# Altium schematic sheet Power Distribution - Misc Boards.SchDoc("Power Distribution - Misc Boards")
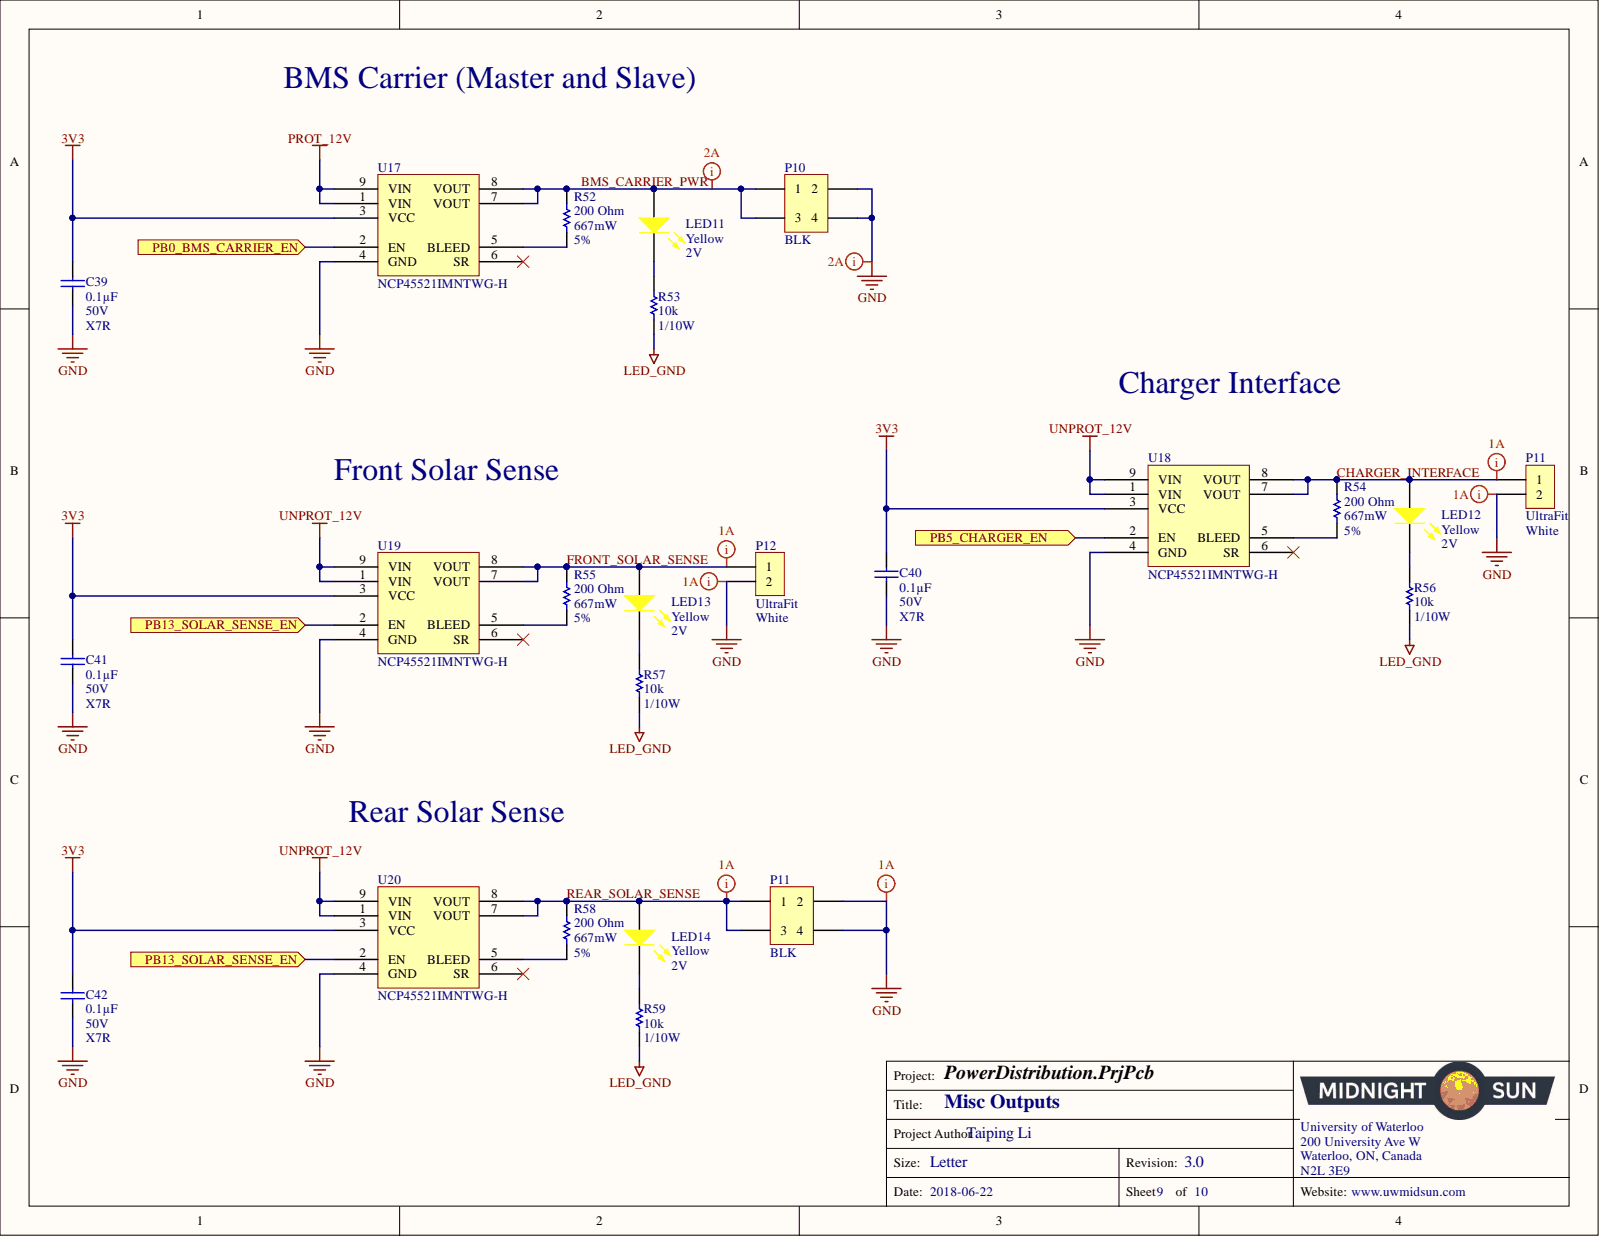
[diagram p0: Power Distribution - Misc Boards.SchDoc]

## Parts
C39  Voltage: 50V  Temperature Coefficient: X7R  Capacitance: 0.1µF  [CAP, 0603]  (hidden comment: CAP CER 0.1UF 50V 10% X7R 0603)
C40  Voltage: 50V  Temperature Coefficient: X7R  Capacitance: 0.1µF  [CAP, 0603]  (hidden comment: CAP CER 0.1UF 50V 10% X7R 0603)
C41  Voltage: 50V  Temperature Coefficient: X7R  Capacitance: 0.1µF  [CAP, 0603]  (hidden comment: CAP CER 0.1UF 50V 10% X7R 0603)
C42  Voltage: 50V  Temperature Coefficient: X7R  Capacitance: 0.1µF  [CAP, 0603]  (hidden comment: CAP CER 0.1UF 50V 10% X7R 0603)
LED11  Voltage - Forward (Vf) (Typ): 2V  Color: Yellow  [LED_0603]  (hidden comment: LED YELLOW CLEAR 2.1V 0603)
LED12  Voltage - Forward (Vf) (Typ): 2V  Color: Yellow  [LED_0603]  (hidden comment: LED YELLOW CLEAR 2.1V 0603)
LED13  Voltage - Forward (Vf) (Typ): 2V  Color: Yellow  [LED_0603]  (hidden comment: LED YELLOW CLEAR 2.1V 0603)
LED14  Voltage - Forward (Vf) (Typ): 2V  Color: Yellow  [LED_0603]  (hidden comment: LED YELLOW CLEAR 2.1V 0603)
P10  BLK  [CONN, 4POS 2 ROW ULTRA-FIT]
P11  Series: UltraFit  Color: White  [CONN, 2POS ULTRA-FIT - NATURAL COLOR]  (hidden comment: CONN 2POS ULTRA-FIT NATURAL COLOR 0.138")
P11  BLK  [CONN, 4POS 2 ROW ULTRA-FIT]
P12  Series: UltraFit  Color: White  [CONN, 2POS ULTRA-FIT - NATURAL COLOR]  (hidden comment: CONN 2POS ULTRA-FIT NATURAL COLOR 0.138")
R52  200 Ohm  Tolerance: 5%  Max Power Dissipation: 667mW  [RES 1206]
R53  Resistance: 10k  Power: 1/10W  [RES, 0603]  (hidden comment: RES 10K OHM 1% 1/10W 0603)
R54  200 Ohm  Tolerance: 5%  Max Power Dissipation: 667mW  [RES 1206]
R55  200 Ohm  Tolerance: 5%  Max Power Dissipation: 667mW  [RES 1206]
R56  Resistance: 10k  Power: 1/10W  [RES, 0603]  (hidden comment: RES 10K OHM 1% 1/10W 0603)
R57  Resistance: 10k  Power: 1/10W  [RES, 0603]  (hidden comment: RES 10K OHM 1% 1/10W 0603)
R58  200 Ohm  Tolerance: 5%  Max Power Dissipation: 667mW  [RES 1206]
R59  Resistance: 10k  Power: 1/10W  [RES, 0603]  (hidden comment: RES 10K OHM 1% 1/10W 0603)
U17  IC LOAD SWITCH ACT-HI 10.5A 8DFN  [IC LOAD SWITCH ACT-HI 10.5A 8DFN]  (value not drawn)
U18  IC LOAD SWITCH ACT-HI 10.5A 8DFN  [IC LOAD SWITCH ACT-HI 10.5A 8DFN]  (value not drawn)
U19  IC LOAD SWITCH ACT-HI 10.5A 8DFN  [IC LOAD SWITCH ACT-HI 10.5A 8DFN]  (value not drawn)
U20  IC LOAD SWITCH ACT-HI 10.5A 8DFN  [IC LOAD SWITCH ACT-HI 10.5A 8DFN]  (value not drawn)

## Ports
PB0_BMS_CARRIER_EN, PB5_CHARGER_EN, PB13_SOLAR_SENSE_EN

## Nets
3V3: C39.1 C40.1 C41.1 C42.1 U17.3(VCC) U18.3(VCC) U19.3(VCC) U20.3(VCC)
GND: C39.2 C40.2 C41.2 C42.2 P10.2 P10.4 P11.2 P11.4 P12.2 U17.4(GND) U18.4(GND) U19.4(GND) U20.4(GND)
LED_GND: R53.2 R56.2 R57.2 R59.2
PROT_12V: U17.1(VIN) U17.9(VIN)
UNPROT_12V: U18.1(VIN) U18.9(VIN) U19.1(VIN) U19.9(VIN) U20.1(VIN) U20.9(VIN)
BMS_CARRIER_PWR: LED11.1 P10.1 P10.3 R52.1 U17.7(VOUT) U17.8(VOUT)
CHARGER_INTERFACE: LED12.1 P11.1 R54.1 U18.7(VOUT) U18.8(VOUT)
FRONT_SOLAR_SENSE: LED13.1 P12.1 R55.1 U19.7(VOUT) U19.8(VOUT)
PB0_BMS_CARRIER_EN: U17.2(EN) ; port PB0_BMS_CARRIER_EN
PB5_CHARGER_EN: U18.2(EN) ; port PB5_CHARGER_EN
PB13_SOLAR_SENSE_EN: U19.2(EN) U20.2(EN) ; port PB13_SOLAR_SENSE_EN
REAR_SOLAR_SENSE: LED14.1 P11.1 P11.3 R58.1 U20.7(VOUT) U20.8(VOUT)
NetLED11_2: LED11.2 R53.1
NetLED12_2: LED12.2 R56.1
NetLED13_2: LED13.2 R57.1
NetLED14_2: LED14.2 R59.1
NetR52_2: R52.2 U17.5(BLEED)
NetR54_2: R54.2 U18.5(BLEED)
NetR55_2: R55.2 U19.5(BLEED)
NetR58_2: R58.2 U20.5(BLEED)

Not connected: U17 6(SR); U18 6(SR); U19 6(SR); U20 6(SR)

## Library data of the parts
C39-C42 = CAP CER 0.1UF 50V 10% X7R 0603: 0.10µF ±10% 50V Ceramic Capacitor X7R 0603 (1608 Metric); Manufacturer Part Number 1: 06035C104KAT2A; Manufacturer 1: AVX Corporation; Tolerance: ±10%; Thickness (Max): 0.035" (0.90mm); Supplier Part Number 1: 478-5052-1-ND; Supplier 1: Digi-Key; Size / Dimension: 0.063" L x 0.032" W (1.60mm x 0.81mm); Ratings: -; Part Status: Active; Packaging: Cut Tape (CT); Package / Case: 0603 (1608 Metric); Operating Temperature: -55°C ~ 125°C; Mounting Type: Surface Mount, MLCC; Lead Style: -; Lead Spacing: -; Height - Seated (Max): -; ComponentLink1URL: http://datasheets.avx.com/X7RDielectric.pdf; ComponentLink1Description: http://datasheets.avx.com/X7RDielectric.pdf; Component Kind: Standard; Library Name: Schematic Diagrams.SchLib
LED11-LED14 = LED YELLOW CLEAR 2.1V 0603: Yellow 590nm LED Indication - Discrete 2V 0603 (1608 Metric); Manufacturer Part Number: 150060YS75000; Manufacturer: Wurth Electronics Inc.; Wavelength - Peak: 595nm; Viewing Angle: 140°; Millicandela Rating: 120mcd; Lens Style/Size: Rectangle with Flat Top, 1.10mm x 0.70mm; Lens Color: Colorless; Current - Test: 20mA; Wavelength - Dominant: 590nm; Supplier Part Number 1: 732-4981-1-ND; Supplier Device Package: 0603; Supplier 1: Digi-Key; Stock 1: 10523; Size / Dimension: 1.60mm L x 0.80mm W; RoHS: RoHS Compliant; Pricing 1: 1=0.21, 100=0.1617, 500=0.13964 (CAD); Part Status: Active; Packaging: Cut Tape (CT); Package / Case: 0603 (1608 Metric); Mounting Type: Surface Mount; Lens Transparency: Clear; Height (Max): 0.80mm; Features: -; ComponentLink1URL: http://katalog.we-online.de/led/datasheet/150060YS75000.pdf; ComponentLink1Description: http://katalog.we-online.de/led/datasheet/150060YS75000.pdf; Component Kind: Standard; Category: Optoelectronics; Library Name: Schematic Diagrams.SchLib
P10 P11 = CONN 4POS 2 ROW ULTRA-FIT 0.138": Connector Header Through Hole 4 position 0.138" (3.50mm); Manufacturer Part Number 1: 1722991104; Manufacturer 1: Molex; Row Spacing: 0.181inch; Pitch: 0.138inch; Number of Positions: 4; Description 1: ULTRA-FIT HDR VT DUAL F BLK 04CKT TIN; Connector Type: Male Pin; Termination: Solder; Supplier Part Number 1: WM11777-ND; Supplier 1: Digi-Key; Stock 1: 958; Pricing 1: 1=0.86, 10=0.753, 100=0.6494, 500=0.53136, 1000=0.47232, 5000=0.41328, 10000=0.39262 (USD); Packaging: Tray; Number of Rows: 2; Mount: Through Hole; Fastening Type: Latch; Contact Plating: Tin; ComponentLink1URL: http://www.literature.molex.com/SQLImages/kelmscott/Molex/PDF_Images/987651-1008.pdf; ComponentLink1Description: http://www.literature.molex.com/SQLImages/kelmscott/Molex/PDF_Images/987651-1008.pdf; Component Kind: Standard; Colour: Black; Library Name: Schematic Diagrams.SchLib
P11 P12 = CONN 2POS ULTRA-FIT NATURAL COLOR 0.138": 2 Positions Header, Shrouded Connector 0.138" (3.50mm) Through Hole; Manufacturer Part Number 1: 1722872102; Manufacturer 1: Molex, LLC; Voltage: 400V; Style: Board to Cable/Wire; Shrouding: Shrouded - 4 Wall; Number of Positions Loaded: All; Number of Positions: 2; Material Flammability Rating: UL94 V-0; Mated Stacking Heights: 26.99mm; Contact Length - Post: 0.126" (3.20mm); Termination: Solder; Supplier Part Number 1: WM11722-ND; Supplier 1: Digi-Key; Row Spacing - Mating: -; Pitch - Mating: 0.138" (3.50mm); Part Status: Active; Packaging: Tray; Overall Contact Length: -; Operating Temperature: -40°C ~ 105°C; Number of Rows: 1; Mounting Type: Through Hole; Insulation Material: Liquid Crystal Polymer (LCP); Insulation Height: 0.465" (11.80mm); Insulation Color: Natural; Ingress Protection: -; Fastening Type: Latch Holder; Current Rating: -; Contact Type: Male Pin; Contact Shape: Square; Contact Material: Copper Alloy; Contact Length - Mating: -; Contact Finish Thickness - Post: 60µin (1.52µm); Contact Finish Thickness - Mating: 60µin (1.52µm); Contact Finish - Post: Tin; Contact Finish - Mating: Tin; Connector Type: Header; ComponentLink1URL: http://www.molex.com/pdm_docs/sd/1722872102_sd.pdf; ComponentLink1Description: http://www.molex.com/pdm_docs/sd/1722872102_sd.pdf; Component Kind: Standard; Library Name: Schematic Diagrams.SchLib
R52 R54 R55 R58 = RES 200 OHM 5% 2/3W 1206: 200 Ohms ±5% 0.667W, 2/3W Chip Resistor 1206 (3216 Metric) Automotive AEC-Q200, Pulse Withstanding Thick Film; Manufacturer Part Number: ERJ-P08J201V; Manufacturer: Panasonic; Voltage Rating (DC): 200V; Voltage Rating: 500V; Temperature Coefficient: 200ppm/°C; Resistance: 200R; Power Rating: 660mW; Width: 1.6mm; Termination: SMD/SMT; Supplier Part Number 1: P200ALCT-ND; Supplier 1: Digi-Key; Stock 1: 32165; Radiation Hardening: No; Pricing 1: 1=0.16, 10=0.137, 100=0.0534, 1000=0.02237, 2500=0.02051 (USD); Packaging: Tape and Reel; Package Quantity: 1; Number of Terminations: 2; Mount: Surface Mount; Min Operating Temperature: -55°C; Max Operating Temperature: 155°C; Length: 3.2mm; Lead Free: Contains Lead; Height: 0.028inch; Contact Plating: Tin; Composition: Thick Film; ComponentLink4URL: https://datasheet.ciiva.com/30201/aoa0000ce4-30201485.pdf; ComponentLink4Description: https://datasheet.ciiva.com/30201/aoa0000ce4-30201485.pdf; ComponentLink3URL: https://datasheet.ciiva.com/9184/aoa0000ce4-9184603.pdf; ComponentLink3Description: https://datasheet.ciiva.com/9184/aoa0000ce4-9184603.pdf; ComponentLink2URL: https://datasheet.ciiva.com/9184/aoa0000ce4-9184599.pdf; ComponentLink2Description: https://datasheet.ciiva.com/9184/aoa0000ce4-9184599.pdf; ComponentLink1URL: https://datasheet.ciiva.com/17207/aoa0000ce4-17207029.pdf; ComponentLink1Description: https://datasheet.ciiva.com/17207/aoa0000ce4-17207029.pdf; Component Kind: Standard; Case Code (Metric): 3216; Case Code (Imperial): 1206; Case/Package: 1206; Library Name: Schematic Diagrams.SchLib
R53 R56 R57 R59 = RES 10K OHM 1% 1/10W 0603: RES SMD 10K OHM 1% 1/10W 0603; Manufacturer Part Number 1: RC0603FR-0710KL; Manufacturer 1: Yageo; Temperature Coefficient: ±100ppm/°C; Tolerance: ±1%; Supplier Part Number 1: 311-10.0KHRCT-ND; Supplier Device Package: 0603; Supplier 1: Digi-Key; Size / Dimension: 0.063" L x 0.031" W (1.60mm x 0.80mm); Part Status: Active; Packaging: Cut Tape (CT); Package / Case: 0603 (1608 Metric); Operating Temperature: -55°C ~ 155°C; Height - Seated (Max): 0.022" (0.55mm); Failure Rate: -; Composition: Thick Film; ComponentLink1URL: http://www.yageo.com/documents/recent/PYu-RC_Group_51_RoHS_L_7.pdf; ComponentLink1Description: http://www.yageo.com/documents/recent/PYu-RC_Group_51_RoHS_L_7.pdf; Component Kind: Standard; Library Name: Schematic Diagrams.SchLib
U17-U20 = IC LOAD SWITCH ACT-HI 10.5A 8DFN: NCP45521IMNTWG-H; Manufacturer: ON Semiconductor; Voltage - Supply (Vcc/Vdd): 3 V ~ 5.5 V; Voltage - Load: 0.5 V ~ 13.5 V; Switch Type: General Purpose; Rds On (Typ): 12.8 mOhm; Ratio - Input: 1:1; Output Type: N-Channel; Output Configuration: High Side; Number of Outputs: 1; Interface: On/Off; Input Type: Non-Inverting; Fault Protection: Over Temperature, UVLO; Supplier Part Number 2: NCP45521IMNTWG-HOSDKR-ND; Supplier Device Package: 8-DFN (2x2); Supplier 2: Digi-Key; Supplier 1: Digi-Key; Stock 2: 2211; Stock 1: 2377; RoHS: RoHS Compliant; Pricing 2: 1=1.53, 10=1.372, 100=1.0702, 500=0.88408, 1000=0.69797 (CAD); Pricing 1: 1=1.57, 10=1.405, 100=1.0957, 500=0.90518, 1000=0.71462 (CAD); Part Status: Active; Packaging: Digi-Reel®; Package / Case: 8-VFDFN Exposed Pad; Operating Temperature: -40°C ~ 85°C (TA); Manufacturer Part Number: NCP45521IMNTWG-H; Features: Slew Rate Controlled; Current - Output (Max): 10.5A; ComponentLink1URL: http://www.onsemi.com/pub/Collateral/NCP45520-D.PDF; ComponentLink1Description: http://www.onsemi.com/pub/Collateral/NCP45520-D.PDF; Component Kind: Standard; Category: Integrated Circuits (ICs); Library Name: Schematic Diagrams.SchLib
